# Altium schematic sheet DEVICES2.SchDoc
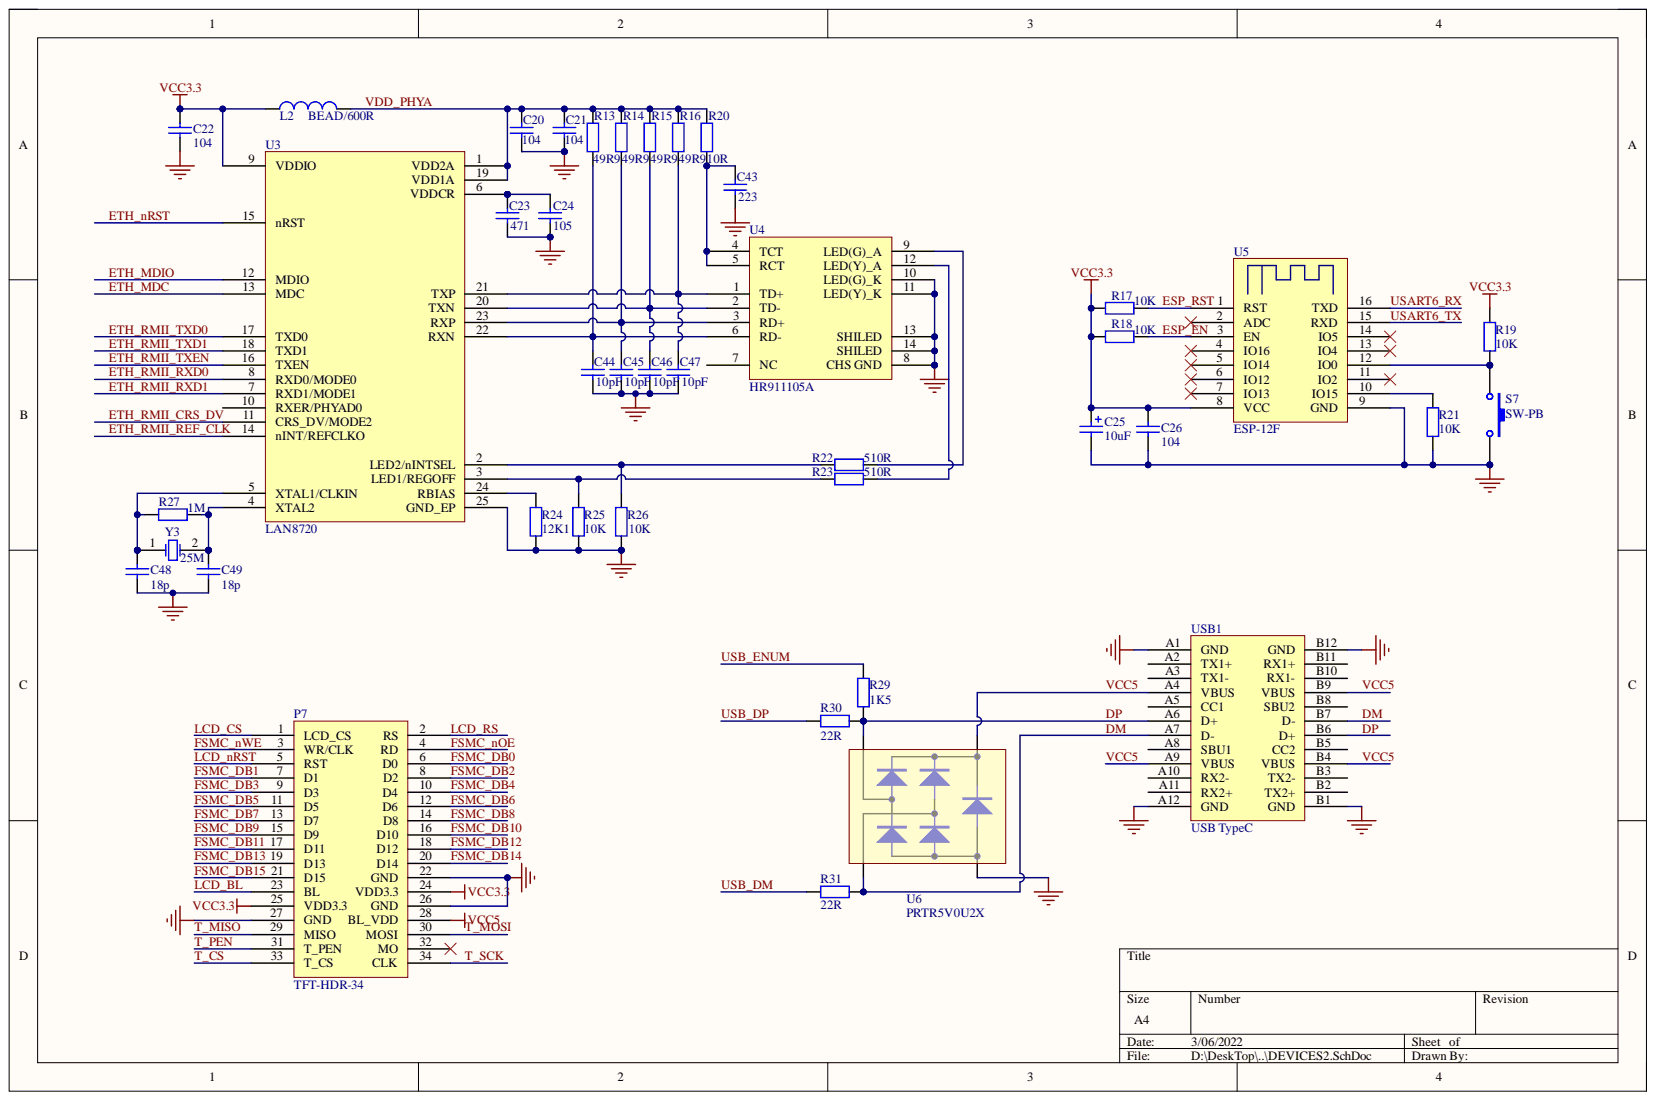
[diagram p0: DEVICES2.SchDoc]

## Parts
C20  104  [C0603]
C21  104  [C0603]
C22  104  [C0603]
C23  471  [C0805]
C24  105  [C0805]
C25  10uF  [C3216]
C26  104  [C0603]
C43  223  [C0805]
C44  10pF  [C0805]
C45  10pF  [C0805]
C46  10pF  [C0805]
C47  10pF  [C0805]
C48  18p  [C0603]
C49  18p  [C0603]
L2  BEAD/600R  [L0805]
P7  TFT-HDR-34  [TFT_PORT]
R13  49R9  [R0805]
R14  49R9  [R0805]
R15  49R9  [R0805]
R16  49R9  [R0805]
R17  10K  [R0603]
R18  10K  [R0603]
R19  10K  [R0603]
R20  10R  [R0805]
R21  10K  [R0603]
R22  510R  [R0805]
R23  510R  [R0805]
R24  12K1  [R0805]
R25  10K  [R0603]
R26  10K  [R0603]
R27  1M  [R0805]
R29  1K5  [R0603]
R30  22R  [R0603]
R31  22R  [R0603]
S7  SW-PB  [Switch]
U3  LAN8720  [QFN24]
U4  HR911105A  [HR911105A]
U5  ESP-12F  [ESP-12F]
U6  PRTR5V0U2X  [SOT143]
USB1  USB TypeC  [USB TYPEC]
Y3  25M  [CY5032]

## Nets
GND: C20.2 C21.2 C22.2 C23.2 C24.2 C25.2 C26.2 C43.2 C44.2 C45.2 C46.2 C47.2 C48.1 C49.1 P7.22(GND) P7.26(GND) P7.27(GND) R21.2 R24.1 R25.1 R26.1 S7.2 U3.25(GND_EP) U4.8(CHS_GND) U4.10(LED(G)_K) U4.11(LED(Y)_K) U4.13(SHILED) U4.14(SHILED) U5.9(GND) U6.1 USB1.A1(GND) USB1.A12(GND) USB1.B1(GND) USB1.B12(GND)
VCC3.3: C22.1 C25.1 C26.1 L2.1 P7.24(VDD3.3) P7.25(VDD3.3) R17.2 R18.2 R19.1 U3.9(VDDIO) U5.8(VCC)
DM: R31.2 U6.2 USB1.A7(D-) USB1.B7(D-)
DP: R29.2 R30.2 U6.3 USB1.A6(D+) USB1.B6(D+)
ESP_EN: R18.1 U5.3(EN)
ESP_RST: R17.1 U5.1(RST)
ETH_MDC: U3.13(MDC)
ETH_MDIO: U3.12(MDIO)
ETH_nRST: U3.15(nRST)
ETH_RMII_CRS_DV: U3.11(CRS_DV/MODE2)
ETH_RMII_REF_CLK: U3.14(nINT/REFCLKO)
ETH_RMII_RXD0: U3.8(RXD0/MODE0)
ETH_RMII_RXD1: U3.7(RXD1/MODE1)
ETH_RMII_TXD0: U3.17(TXD0)
ETH_RMII_TXD1: U3.18(TXD1)
ETH_RMII_TXEN: U3.16(TXEN)
FSMC_DB0: P7.6(D0)
FSMC_DB1: P7.7(D1)
FSMC_DB2: P7.8(D2)
FSMC_DB3: P7.9(D3)
FSMC_DB4: P7.10(D4)
FSMC_DB5: P7.11(D5)
FSMC_DB6: P7.12(D6)
FSMC_DB7: P7.13(D7)
FSMC_DB8: P7.14(D8)
FSMC_DB9: P7.15(D9)
FSMC_DB10: P7.16(D10)
FSMC_DB11: P7.17(D11)
FSMC_DB12: P7.18(D12)
FSMC_DB13: P7.19(D13)
FSMC_DB14: P7.20(D14)
FSMC_DB15: P7.21(D15)
FSMC_nOE: P7.4(RD)
FSMC_nWE: P7.3(WR/CLK)
LCD_BL: P7.23(BL)
LCD_CS: P7.1(LCD_CS)
LCD_nRST: P7.5(RST)
LCD_RS: P7.2(RS)
T_CS: P7.33(T_CS)
T_MISO: P7.29(MISO)
T_MOSI: P7.30(MOSI)
T_PEN: P7.31(T_PEN)
T_SCK: P7.34(CLK)
USART6_RX: U5.16(TXD)
USART6_TX: U5.15(RXD)
USB_DM: R31.1
USB_DP: R30.1
USB_ENUM: R29.1
VCC5: P7.28(BL_VDD) U6.4 USB1.A4(VBUS) USB1.A9(VBUS) USB1.B4(VBUS) USB1.B9(VBUS)
VDD_PHYA: C20.1 C21.1 L2.2 R13.2 R14.2 R15.2 R16.2 R20.2 U3.1(VDD2A) U3.19(VDD1A)
NetC23_1: C23.1 C24.1 U3.6(VDDCR)
NetC43_1: C43.1 R20.1 U4.4(TCT) U4.5(RCT)
NetC44_1: C44.1 R13.1 U3.22(RXN) U4.6(RD-)
NetC45_1: C45.1 R14.1 U3.23(RXP) U4.3(RD+)
NetC46_1: C46.1 R15.1 U3.20(TXN) U4.2(TD-)
NetC47_1: C47.1 R16.1 U3.21(TXP) U4.1(TD+)
NetC48_2: C48.2 R27.2 U3.5(XTAL1/CLKIN) Y3.1
NetC49_2: C49.2 R27.1 U3.4(XTAL2) Y3.2
NetR19_2: R19.2 S7.1 U5.12(IO0)
NetR21_1: R21.1 U5.10(IO15)
NetR22_1: R22.1 U4.9(LED(G)_A)
NetR22_2: R22.2 R26.2 U3.2(LED2/nINTSEL)
NetR23_1: R23.1 U4.12(LED(Y)_A)
NetR23_2: R23.2 R25.2 U3.3(LED1/REGOFF)
NetR24_2: R24.2 U3.24(RBIAS)

Not connected: P7 32(MO); U3 10(RXER/PHYAD0); U4 7(NC); U5 2(ADC) 4(IO16) 5(IO14) 6(IO12) 7(IO13) 11(IO2) 13(IO4) 14(IO5); USB1 A2(TX1+) A3(TX1-) A5(CC1) A8(SBU1) A10(RX2-) A11(RX2+) B2(TX2+) B3(TX2-) B5(CC2) B8(SBU2) B10(RX1-) B11(RX1+)

## Library data of the parts
C20-C24 C43-C49 = Cap: Capacitor; Value: 100pF; Component Kind: Standard; LatestRevisionDate: 17-Jul-2002; LatestRevisionNote: Re-released for DXP Platform.; Library Name: MainBoard.SCHLIB; PackageDescription: Radial Cap, Thru-Hole; 2 Leads; 0.3 in Pin Spacing; PackageReference: RAD-0.3; Published: 8-Jun-2000; Publisher: Altium Limited
C25 = Cap Pol2: 电解电容; Component Kind: Standard; LatestRevisionDate: 17-Jul-2002; LatestRevisionNote: Re-released for DXP Platform.; Library Name: UV_DMB2.SCHLIB; PackageReference: POLAR0.8; Published: 8-Jun-2000; Signal Integrity: Cap Pol; Simulation: CAP; Publisher: Altium Limited
C26 = Cap: 电容; Component Kind: Standard; LatestRevisionDate: 17-Jul-2002; LatestRevisionNote: Re-released for DXP Platform.; Library Name: UV_DMB2.SCHLIB; PackageReference: RAD-0.3; Published: 8-Jun-2000; Signal Integrity: Cap; Simulation: CAP; Publisher: Altium Limited
L2 = Inductor: 磁珠; Code_IEC: 1005; Value: 10mH; Code_JEITA: 0402; Component Kind: Standard; LatestRevisionDate: 29-May-2009; LatestRevisionNote: IPC-7351 Footprint Added.; Library Name: MainBoard.SCHLIB; PackageDescription: Chip Inductor; PackageReference: 0402-A; Published: 8-Jun-2000; Signal Integrity: Inductor; Simulation: INDUCTOR; Publisher: Altium Limited
R13-R16 R20 R22-R27 R29-R31 = Res: Resistor; Component Kind: Standard; LatestRevisionDate: 17-Jul-2002; LatestRevisionNote: Re-released for DXP Platform.; Library Name: MainBoard.SCHLIB; PackageDescription: Axial Device, Thru-Hole; 2 Leads; 0.4 in Pin Spacing; PackageReference: AXIAL-0.4; Published: 8-Jun-2000; Publisher: Altium Limited
R17-R19 R21 = Res: 电阻; Value: 1K; Component Kind: Standard; LatestRevisionDate: 17-Jul-2002; LatestRevisionNote: Re-released for DXP Platform.; Library Name: UV_DMB2.SCHLIB; PackageReference: R0603; Published: 8-Jun-2000; Signal Integrity: Res; Simulation: RESISTOR; Publisher: Altium Limited
S7 = SW-PB: Switch; Component Kind: Standard; LatestRevisionDate: 17-Jul-2002; LatestRevisionNote: Re-released for DXP Platform.; Library Name: Miscellaneous Devices.IntLib; PackageReference: SPST-2; Published: 24-Mar-1999; Publisher: Altium Limited
U3 = LAN8720: 网络收发器; Component Kind: Standard; Library Name: MainBoard.SchLib
U4 = HR911105: Component Kind: Standard; Library Name: MainBoard.SchLib
U5 = ESP-12F: ESP8266 WIFI MODULE; Component Kind: Standard; Library Name: UV_DMB2.SCHLIB
USB1 = USB-C: Component Kind: Standard; Library Name: 5328p.SchLib
Y3 = XTAL: Crystal Oscillator; Component Kind: Standard; LatestRevisionDate: 17-Jul-2002; LatestRevisionNote: Re-released for DXP Platform.; Library Name: MainBoard.SCHLIB; PackageDescription: Crystal, Thru-Hole; 2 Leads; Body 3.1 x 8.2 mm (Dia.xH); PackageReference: R38; Published: 8-Jun-2000; Publisher: Altium Limited
P7 = TFT-HDR-34: Component Kind: Standard; Library Name: MainBoard.SCHLIB
U6 = PRTR5V0U2X: Component Kind: Standard; Library Name: MainBoard.SCHLIB
